# Altium schematic sheet ServoBoard_Schematic.SchDoc
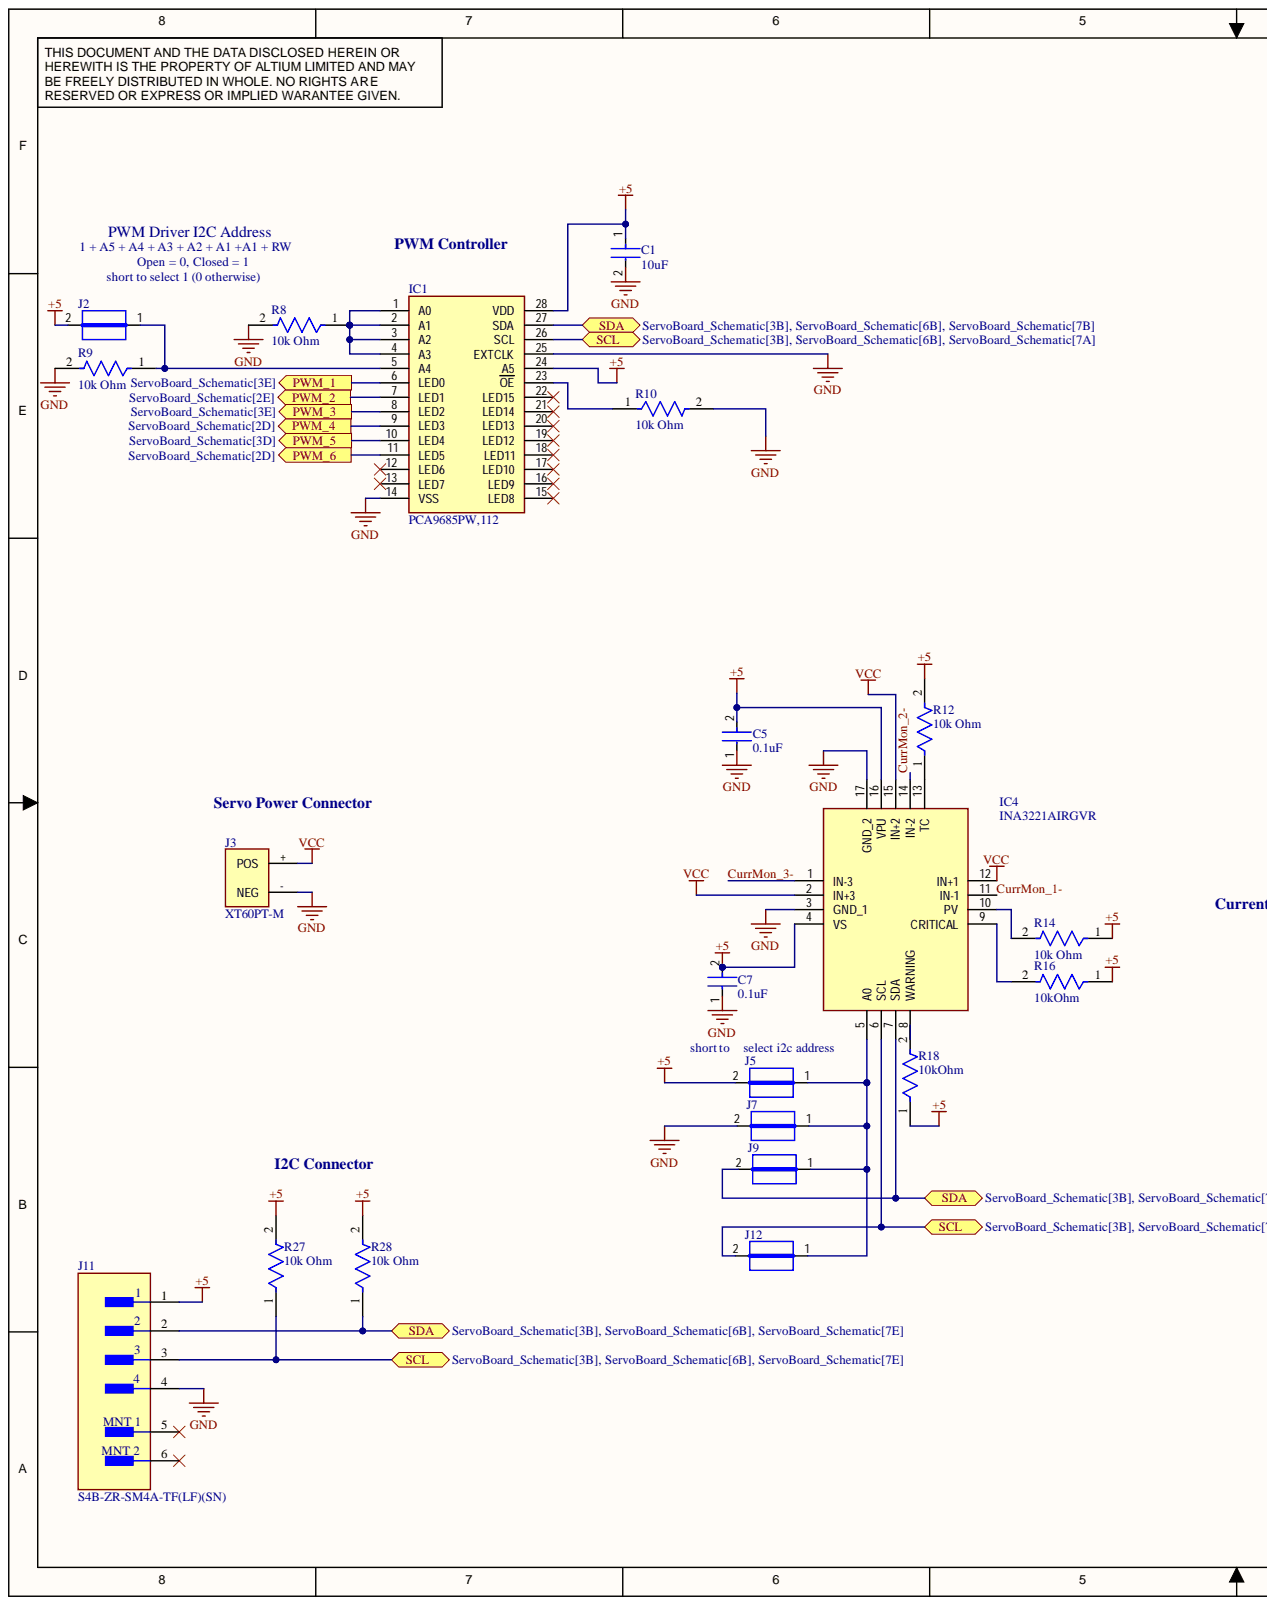
[diagram p0: ServoBoard_Schematic.SchDoc, left]
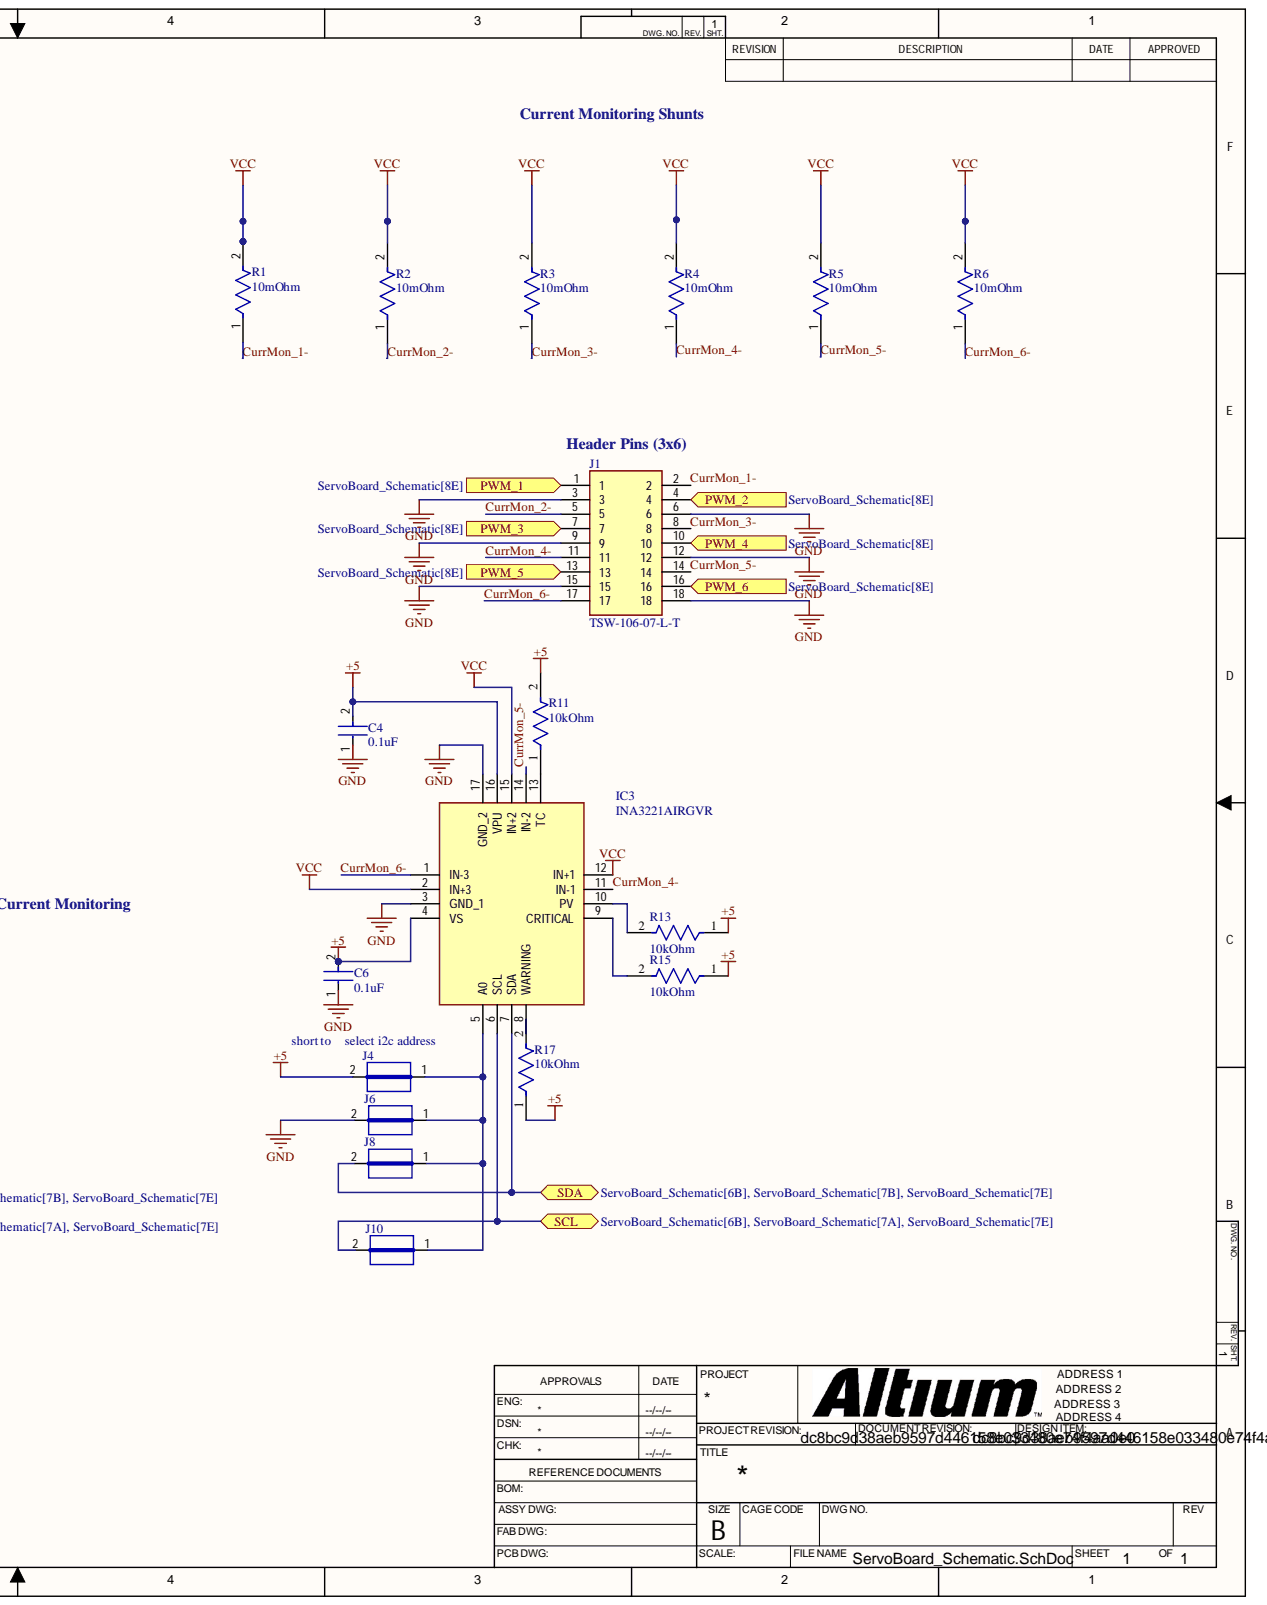
[diagram p1: ServoBoard_Schematic.SchDoc, right]

## Parts
C1  10uF  [FP-GRM188-0_1-e0_2_0_55-IPC_A]
C4  0.1uF  [FP-GCJ188-0_2-IPC_A]
C5  0.1uF  [FP-GCJ188-0_2-IPC_A]
C6  0.1uF  [FP-GCJ188-0_2-IPC_A]
C7  0.1uF  [FP-GCJ188-0_2-IPC_A]
IC1  PCA9685PW,112  [SOP65P640X110-28N]
IC3  INA3221AIRGVR  [QFN65P400X400X100-17N-D]
IC4  INA3221AIRGVR  [QFN65P400X400X100-17N-D]
J1  TSW-106-07-L-T  [HDRV18W64P254_3X6_1524X749X838P]
J2  JUMPER  [JUMPER]  (value not drawn)
J3  XT60PT-M  [XT60PT-M]
J4  JUMPER  [JUMPER]  (value not drawn)
J5  JUMPER  [JUMPER]  (value not drawn)
J6  JUMPER  [JUMPER]  (value not drawn)
J7  JUMPER  [JUMPER]  (value not drawn)
J8  JUMPER  [JUMPER]  (value not drawn)
J9  JUMPER  [JUMPER]  (value not drawn)
J10  JUMPER  [JUMPER]  (value not drawn)
J11  S4B-ZR-SM4A-TF(LF)(SN)  [FP-S4B-ZR-SM4A-TF_LF_SN-MFG]
J12  JUMPER  [JUMPER]  (value not drawn)
R1  10mOhm  [FP-ERJ8CW-MFG]
R2  10mOhm  [FP-ERJ8CW-MFG]
R3  10mOhm  [FP-ERJ8CW-MFG]
R4  10mOhm  [FP-ERJ8CW-MFG]
R5  10mOhm  [FP-ERJ8CW-MFG]
R6  10mOhm  [FP-ERJ8CW-MFG]
R8  10k Ohm  [FP-ERA3A-IPC_C]
R9  10k Ohm  [FP-ERA3A-IPC_C]
R10  10k Ohm  [FP-ERA3A-IPC_C]
R11  10kOhm  [FP-ERA3A-IPC_C]
R12  10k Ohm  [FP-ERA3A-IPC_C]
R13  10kOhm  [FP-ERA3A-IPC_C]
R14  10k Ohm  [FP-ERA3A-IPC_C]
R15  10kOhm  [FP-ERA3A-IPC_C]
R16  10kOhm  [FP-ERA3A-IPC_C]
R17  10kOhm  [FP-ERA3A-IPC_C]
R18  10kOhm  [FP-ERA3A-IPC_C]
R27  10k Ohm  [FP-ERA3A-IPC_C]
R28  10k Ohm  [FP-ERA3A-IPC_C]

## Ports
PWM_1, PWM_2, PWM_3, PWM_4, PWM_5, PWM_6, SCL, SDA

## Nets
+5: C1.1 C4.2 C5.2 C6.2 C7.2 IC1.24(A5) IC1.28(VDD) IC3.4(VS) IC3.16(VPU) IC4.4(VS) IC4.16(VPU) J2.2 J4.2 J5.2 J11.1 R11.2 R12.2 R13.1 R14.1 R15.1 R16.1 R17.1 R18.1 R27.2 R28.2
GND: C1.2 C4.1 C5.1 C6.1 C7.1 IC1.14(VSS) IC1.25(EXTCLK) IC3.3(GND_1) IC3.17(GND_2) IC4.3(GND_1) IC4.17(GND_2) J1.3 J1.6 J1.9 J1.12 J1.15 J1.18 J3.- J6.2 J7.2 J11.4 R8.2 R9.2 R10.2
VCC: IC3.2(IN+3) IC3.12(IN+1) IC3.15(IN+2) IC4.2(IN+3) IC4.12(IN+1) IC4.15(IN+2) J3.+ R1.2 R2.2 R3.2 R4.2 R5.2 R6.2
CurrMon_1-: IC4.11(IN-1) J1.2 R1.1
CurrMon_2-: IC4.14(IN-2) J1.5 R2.1
CurrMon_3-: IC4.1(IN-3) J1.8 R3.1
CurrMon_4-: IC3.11(IN-1) J1.11 R4.1
CurrMon_5-: IC3.14(IN-2) J1.14 R5.1
CurrMon_6-: IC3.1(IN-3) J1.17 R6.1
PWM_1: IC1.6(LED0) J1.1 ; port PWM_1
PWM_2: IC1.7(LED1) J1.4 ; port PWM_2
PWM_3: IC1.8(LED2) J1.7 ; port PWM_3
PWM_4: IC1.9(LED3) J1.10 ; port PWM_4
PWM_5: IC1.10(LED4) J1.13 ; port PWM_5
PWM_6: IC1.11(LED5) J1.16 ; port PWM_6
SCL: IC1.26(SCL) IC3.6(SCL) IC4.6(SCL) J10.2 J11.3 J12.2 R27.1 ; port SCL
SDA: IC1.27(SDA) IC3.7(SDA) IC4.7(SDA) J8.2 J9.2 J11.2 R28.1 ; port SDA
NetIC1_1: IC1.1(A0) IC1.2(A1) IC1.3(A2) IC1.4(A3) R8.1
NetIC1_5: IC1.5(A4) J2.1 R9.1
NetIC1_23: IC1.23(OE) R10.1
NetIC3_5: IC3.5(A0) J4.1 J6.1 J8.1 J10.1
NetIC3_8: IC3.8(WARNING) R17.2
NetIC3_9: IC3.9(CRITICAL) R15.2
NetIC3_10: IC3.10(PV) R13.2
NetIC3_13: IC3.13(TC) R11.1
NetIC4_5: IC4.5(A0) J5.1 J7.1 J9.1 J12.1
NetIC4_8: IC4.8(WARNING) R18.2
NetIC4_9: IC4.9(CRITICAL) R16.2
NetIC4_10: IC4.10(PV) R14.2
NetIC4_13: IC4.13(TC) R12.1

Not connected: IC1 12(LED6) 13(LED7) 15(LED8) 16(LED9) 17(LED10) 18(LED11) 19(LED12) 20(LED13) 21(LED14) 22(LED15); J11 5 6

## Library data of the parts
C1 = CMP-2006-02792-2: Chip Multilayer Ceramic Capacitors for General Purpose, 0603, 10uF, X5R, 15%, 20%, 6.3V; Capacitance: 10uF; Case Code (Imperial): 0603; Case Code (Metric): 1608; Case/Package: 0603; Component Kind: Standard; Depth: 0.8mm; Dielectric: X5R; Height: 0.8mm; Lead Free: Lead Free; Length: 1.6mm; Library Name: Altium Content Vault; Material: Ceramic; Max Operating Temperature: 85°C; Min Operating Temperature: -55°C; Mount: Surface Mount; Package Quantity: 4000; Packaging: Tape and Reel; Radiation Hardening: No; REACH SVHC: No SVHC; Termination: SMD/SMT; Thickness: 0.035inch; Tolerance: 20%; Voltage: 6.3V; Voltage Rating: 6.3V; Voltage Rating (DC): 6.3V; Width: 0.031inch
C4-C7 = CMP-06035-047895-1: Cap Ceramic 0.1uF 100V X7R 10% Pad SMD 0603 Soft Termination +125°C Automotive T/R; Capacitance: 100nF; Case Code (Imperial): 0603; Case Code (Metric): 1608; Case/Package: 0603; Component Kind: Standard; Features: Soft Termination; Lead Free: Lead Free; Length: 0.063inch; Library Name: Altium Content Vault; Max Operating Temperature: 125°C; Min Operating Temperature: -55°C; Mount: Surface Mount; Packaging: Tape and Reel; Ratings: AEC-Q200; Thickness: 0.035inch; Tolerance: 10%; Voltage Rating: 100V; Width: 0.031inch
IC1 = PCA9685PW,112: Integrated Circuit; Manufacturer_Name: NXP; Arrow Part Number: PCA9685PW,112; Arrow Price/Stock: https://www.arrow.com/en/products/pca9685pw112/nxp-semiconductors?region=nac; Component Kind: Standard; Datasheet Link: http://www.nxp.com/docs/en/data-sheet/PCA9685.pdf; Height: 1.1mm; Manufacturer_Part_Number: PCA9685PW,112; Mouser Part Number: 771-PCA9685PW,112; Mouser Price/Stock: https://www.mouser.co.uk/ProductDetail/NXP-Semiconductors/PCA9685PW112?qs=6YAT8rHPFU9wZqiAeiOMZw%3D%3D
IC3 IC4 = INA3221AIRGVR: Integrated Circuit; Manufacturer_Name: Texas Instruments; Arrow Part Number: INA3221AIRGVR; Arrow Price/Stock: https://www.arrow.com/en/products/ina3221airgvr/texas-instruments?region=nac; Component Kind: Standard; Datasheet Link: http://www.ti.com/lit/ds/symlink/ina3221.pdf; Height: 1mm; Manufacturer_Part_Number: INA3221AIRGVR; Mouser Part Number: 595-INA3221AIRGVR; Mouser Price/Stock: https://www.mouser.co.uk/ProductDetail/Texas-Instruments/INA3221AIRGVR?qs=3AcK5DacLboHys8907TVUQ%3D%3D
J1 = TSW-106-07-L-T: Connector; Manufacturer_Name: SAMTEC; Arrow Part Number: TSW-106-07-L-T; Arrow Price/Stock: https://www.arrow.com/en/products/tsw-106-07-l-t/samtec; Component Kind: Standard; Datasheet Link: http://suddendocs.samtec.com/catalog_english/tsw_th.pdf; Height: 8.38mm; Manufacturer_Part_Number: TSW-106-07-L-T; Mouser Part Number: 200-TSW10607LT; Mouser Price/Stock: https://www.mouser.co.uk/ProductDetail/Samtec/TSW-106-07-L-T?qs=rU5fayqh%252BE39iyDwG6o8Cg%3D%3D
J2 J4-J10 J12 = CMP-009-00061-2: Component Kind: Standard; Description: JUMPER; Pins: 2; Library Name: Monash Uncrewed Aerial Systems (UAS)
J3 = XT60PT-M: Male SMT XT60 Connector; Component Kind: Standard; Library Name: Daughter_SchLib.SchLib
J11 = CMP-17439-000081-1: CONN HEADER SMD R/A 4POS 1.5MM; Contact Resistance: 30mR; Current Rating: 1A; Gender: Male; Max Voltage Rating (AC): 50V; Number of Contacts: 4; Color: Natural; Component Kind: Standard; Connector Type: Header; Contact Finish - Mating: TIN; Contact Material: Brass; Contact Plating: Copper; Contact Shape: Circular; Contact Type: Male Pin; Depth: 6.5mm; Fastening Type: Detent; Flammability Rating: UL94 V-0; Height: 7.2mm; Housing Color: Beige; Housing Material: Polyamide; Insulation Color: Natural; Insulation Material: Nylon; Lead Free: Lead Free; Length: 9mm; Library Name: Altium Content Vault; Max Current Rating: 1A; Max Operating Temperature: 85°C; Max Voltage Rating (DC): 50V; Min Operating Temperature: -25°C; Mount: Surface Mount; Number of Positions: 4; Number of Rows: 1; Orientation: Right Angle; Packaging: Tape and Reel; Pitch: 1.5mm; Series: ZH; Termination: Solder; Voltage Rating: 50V; Width: 3.5mm
R1-R6 = CMP-2003-00786-2: RES 0.01 OHM 1% 1W 1206; Resistance: 10mR; Temperature Coefficient: 75ppm/°C; Case Code (Imperial): 1206; Case Code (Metric): 3216; Case/Package: 1206; Component Kind: Standard; Depth: 1.6mm; Features: Automotive AEC-Q200; Height: 0.03inch; Lead Free: Lead Free; Length: 3.2mm; Library Name: Altium Content Vault; Max Operating Temperature: 125°C; Max Power Dissipation: 1W; Military Standard: Not; Min Operating Temperature: -55°C; Mount: Surface Mount; Number of Terminations: 2; Packaging: Cut Tape; Power Rating: 1W; REACH SVHC: No SVHC; Resistor Type: Thick Film; Termination: Flat; Tolerance: 1%; Width: 0.063inch
R8-R18 R27 R28 = CMP-2000-00874-2: RES SMD 10K OHM 0.1% 1/10W 0603; Max Power Dissipation: 100mW; Resistance: 10kR; Temperature Coefficient: 25ppm/°C; Voltage Rating: 75V; Case Code (Imperial): 0603; Case Code (Metric): 1608; Case/Package: 0603; Component Kind: Standard; Construction: Rectangular; Contact Plating: Tin; Depth: 0.8mm; Height: 0.45mm; Lead Free: Lead Free; Length: 1.6mm; Library Name: Altium Content Vault; Max Operating Temperature: 155°C; Military Standard: Not; Min Operating Temperature: -55°C; Mount: Surface Mount; Number of Pins: 2; Number of Terminations: 2; Package Quantity: 5000; Packaging: Tape and Reel; Power Rating: 100mW; Radiation Hardening: No; REACH SVHC: No SVHC; Resistance Tolerance: 0.1%; Resistor Type: Thin Film; RoHS Compliant: Yes; Series: ERA-3A; Size Code: 0603; Technology: METAL FILM; Termination: SMD/SMT; Tolerance: 0.1%; Voltage Rating (DC): 75V; Width: 0.8mm
